# Altium schematic sheet Output, Input.SchDoc("Output, Input")
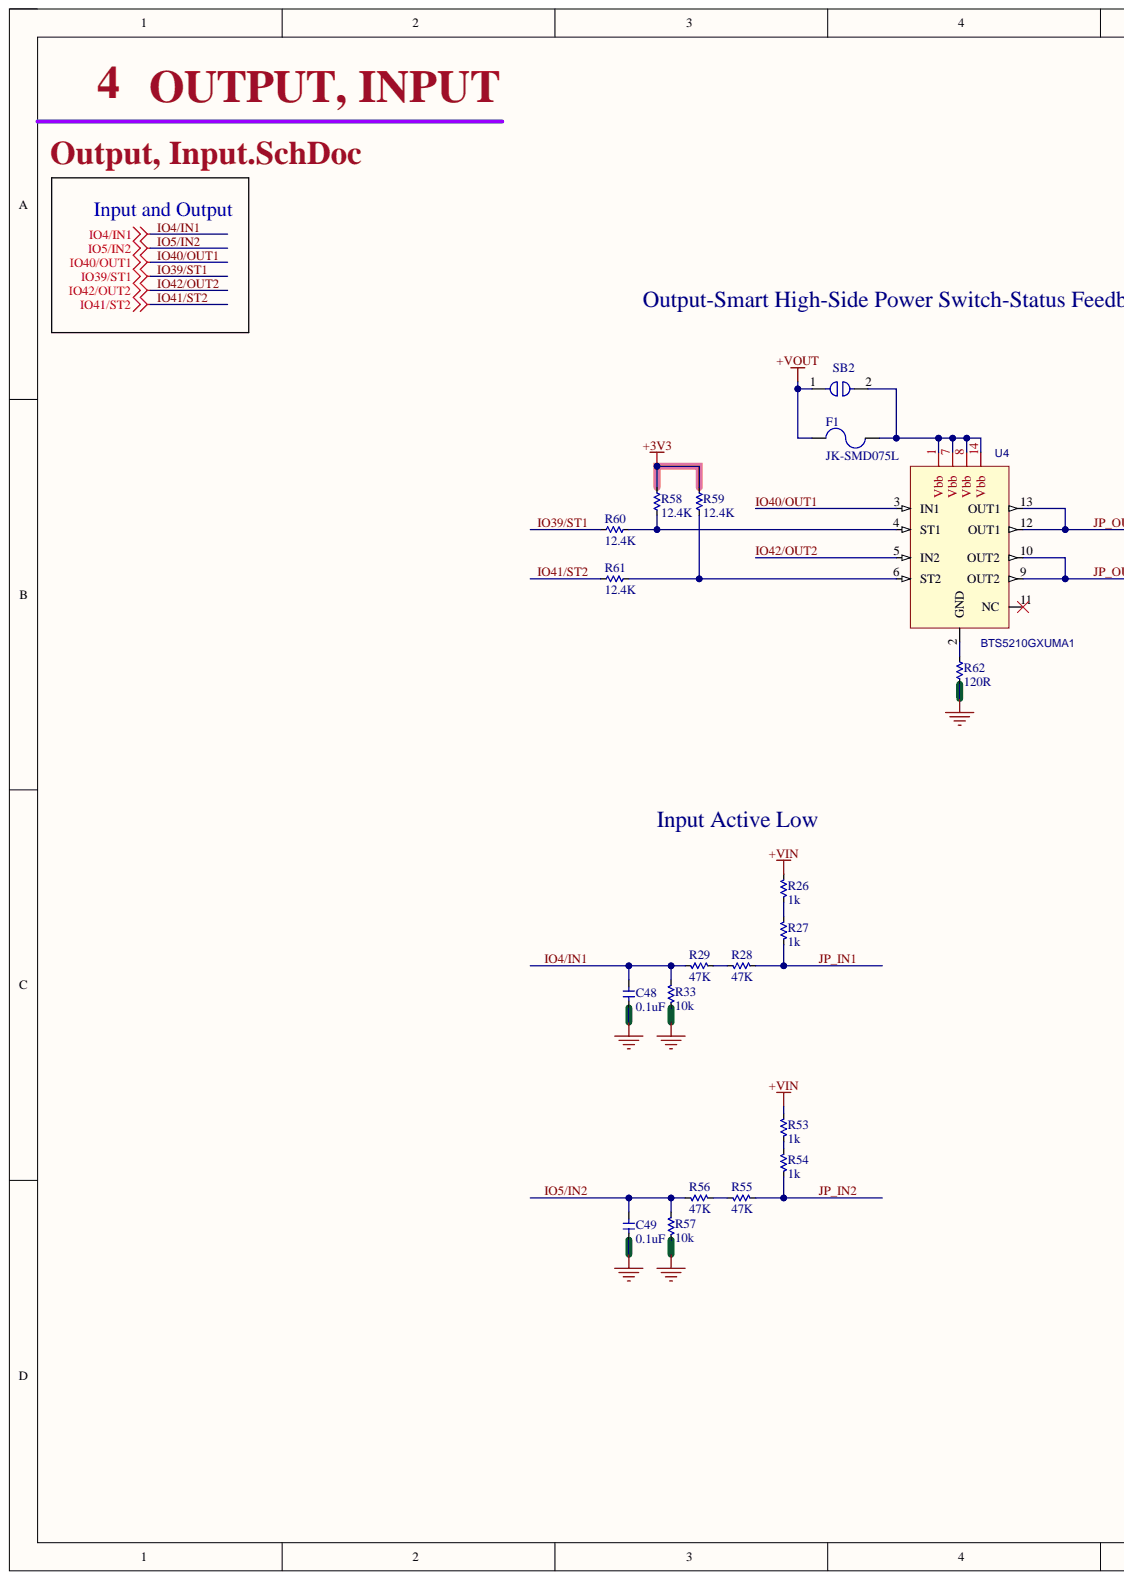
[diagram p0: Output, Input.SchDoc, left]
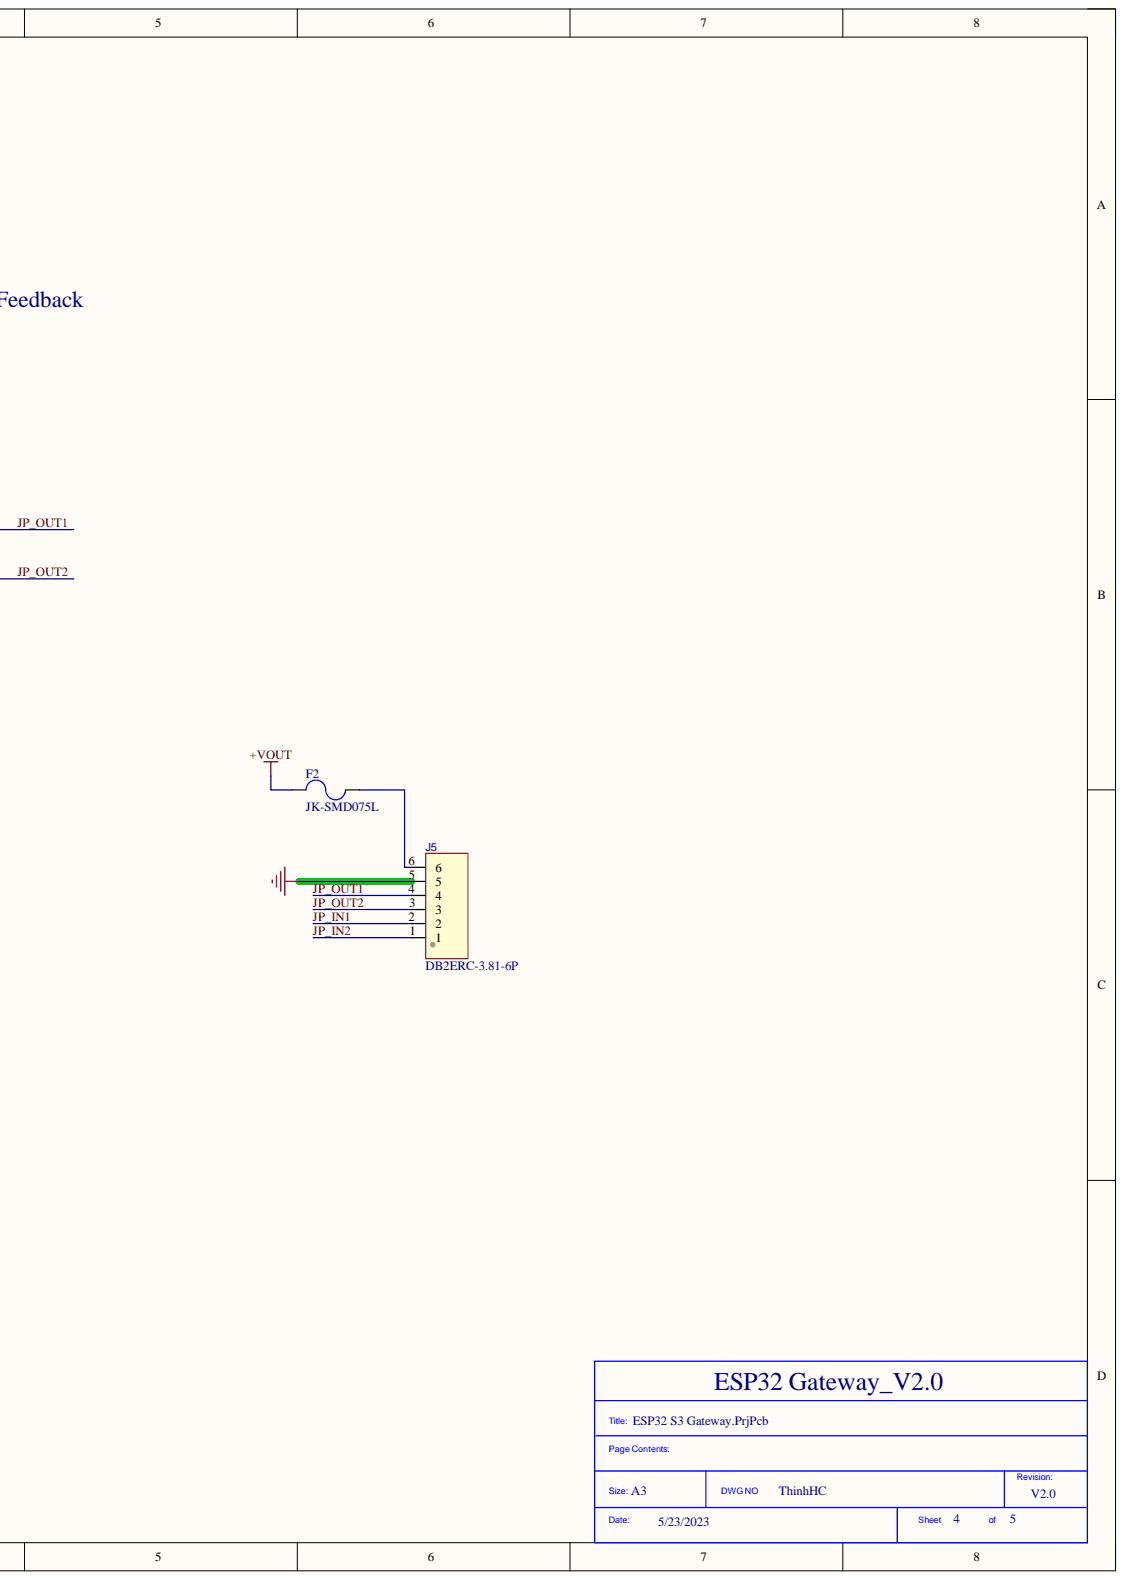
[diagram p1: Output, Input.SchDoc, right]

## Parts
C48  0.1uF  [C_0402]
C49  0.1uF  [C_0402]
F1  JK-SMD075L  [F_1812]
F2  JK-SMD075L  [F_1812]
J5  DB2ERC-3.81-6P  [CONN-TH_6P-P3.81_DB2ERC-3.81-6P]
R26  1k  [R_0603]
R27  1k  [R_0603]
R28  47K  [R_0603]
R29  47K  [R_0603]
R33  10k  [R_0402]
R53  1k  [R_0603]
R54  1k  [R_0603]
R55  47K  [R_0603]
R56  47K  [R_0603]
R57  10k  [R_0402]
R58  12.4K  [R_0402]
R59  12.4K  [R_0402]
R60  12.4K  [R_0402]
R61  12.4K  [R_0402]
R62  120R  [R_0603]
SB2  SOLDERJUMPER_1WAY_OFF  [SOLDERJUMPER_1WAY_OFF]  (value not drawn)  (no BOM)
U4  BTS5210GXUMA1  [PG-DSO-14_L8.8-W4.0-P1.27-LS6.0-BL]

## Nets
+3V3: R58.1 R59.1
+VIN: R26.2 R53.2
+VOUT: F1.1 F2.1 SB2.1
GND: C48.1 C49.1 J5.5 R33.1 R57.1 R62.2
IO4/IN1: C48.2 R29.2 R33.2
IO5/IN2: C49.2 R56.2 R57.2
IO39/ST1: R60.2
IO40/OUT1: U4.3(IN1)
IO41/ST2: R61.1
IO42/OUT2: U4.5(IN2)
JP_IN1: J5.2 R27.1 R28.1
JP_IN2: J5.1 R54.1 R55.1
JP_OUT1: J5.4 U4.12(OUT1) U4.13(OUT1)
JP_OUT2: J5.3 U4.9(OUT2) U4.10(OUT2)
NetF1_2: F1.2 SB2.2 U4.1(Vbb) U4.7(Vbb) U4.8(Vbb) U4.14(Vbb)
NetF2_2: F2.2 J5.6
NetR26_1: R26.1 R27.2
NetR28_2: R28.2 R29.1
NetR53_1: R53.1 R54.2
NetR55_2: R55.2 R56.1
NetR58_2: R58.2 R60.1 U4.4(ST1)
NetR59_2: R59.2 R61.2 U4.6(ST2)
NetR62_1: R62.1 U4.2(GND)

Not connected: U4 11(NC)

## Library data of the parts
C48 C49 = CL05B104KB54PNC: 50V 100nF X7R ±10% 0402 Multilayer Ceramic Capacitors MLCC - SMD/SMT ROHS; Manufacturer: Samsung Electro-Mechanics; Assembly Type: SMT Assembly; Component Kind: Standard; ComponentLink1Description: Digikey Link; ComponentLink1URL: https://www.digikey.com/en/products/detail/yageo/CC0603KRX7R9BB104/2103082?s=N4IgTCBcDaIMwEYEFoFwCzuWZA5AIiALoC%2BQA; ComponentLink2Description: LCSC Link; ComponentLink2URL: https://jlcpcb.com/parts/componentSearch?searchTxt=10k%200603; LCSC Part Number: C307331; Library Name: ESP32 S3 Gateway.SchLib; Manufacturer Part Number: CL05B104KB54PNC; Package: 0402
F1 F2 = PTC_JK-SMD075L: JK-SMD075L 33V 750mA 100A 1.5A 2920  Resettable Fuses ROHS; Manufacturer: Jinrui Electronic Materials Co.; Assembly Type: SMT Assembly; Component Kind: Standard; LCSC Part Number: C920242; Library Name: ESP32 S3 Gateway.SchLib; Manufacturer Part Number: JK-SMD075L; Package: 1812
J5 = DB2ERC-3.81-6P: 250V 10A 6 Bend -40℃~+105℃ 1 3.81mm Green Board Edge/Receptacle-Close Push-Pull,P=3.81mm Pluggable System Terminal Block ROHS; Manufacturer: DIBO; Manufacturer Part Number: DB2ERC-3.81-6P-GN; Assembly Type: Hand Soldering; Component Kind: Standard; LCSC Part Number: C395709; Library Name: ESP32 S3 Gateway.SchLib; Package: Push-Pull,P=3.81mm
R26 R27 R53 R54 = 0603WAF1001T5E: 100mW Thick Film Resistors 75V ±100ppm/℃ ±1% -55℃~+155℃ 1kΩ 0603 Chip Resistor - Surface Mount ROHS; Manufacturer: UNI-ROYAL(Uniroyal Elec); Assembly Type: SMT Assembly; Component Kind: Standard; LCSC Part Number: C21190; Library Name: ESP32 S3 Gateway.SchLib; Manufacturer Part Number: 0603WAF1001T5E; Package: 0603
R28 R29 R55 R56 = 0603WAF4702T5E: 100mW Thick Film Resistors ±1% 47kΩ 0603 Chip Resistor - Surface Mount ROHS; Manufacturer: UNI-ROYAL(Uniroyal Elec); Assembly Type: SMT Assembly; Component Kind: Standard; LCSC Part Number: C25819; Library Name: ESP32 S3 Gateway.SchLib; Manufacturer Part Number: 0603WAF4702T5E; Package: 0603
R33 R57 = 0402WGF1002TCE: 10kΩ 1% 0402 Chip Resistor - Surface Mount ROHS; Manufacturer: UNI-ROYAL(Uniroyal Elec); Assembly Type: SMT Assembly; Component Kind: Standard; LCSC Part Number: C25744; Library Name: ESP32 S3 Gateway.SchLib; Manufacturer Part Number: 0402WGF1002TCE; Package: 0402
R58-R61 = 0402WGF1242TCE: 62.5mW Thick Film Resistors ±1% 12.4kΩ 0402 Chip Resistor - Surface Mount ROHS; Manufacturer: UNI-ROYAL(Uniroyal Elec); Assembly Type: SMT Assembly; Component Kind: Standard; LCSC Part Number: C11692; Library Name: ESP32 S3 Gateway.SchLib; Manufacturer Part Number: 0402WGF1242TCE; Package: 0402
R62 = 0603WAF1200T5E: 100mW Thick Film Resistors 75V ±100ppm/℃ ±1% -55℃~+155℃ 120Ω 0603 Chip Resistor - Surface Mount ROHS; Manufacturer: UNI-ROYAL(Uniroyal Elec); Assembly Type: SMT Assembly; Component Kind: Standard; LCSC Part Number: C22787; Library Name: ESP32 S3 Gateway.SchLib; Manufacturer Part Number: 0603WAF1200T5E; Package: 0603
SB2 = SOLDERJUMPER_1WAY_OFF: GateName_1: G$1; Description: SOLDERJUMPER_1WAY_OFF; DeviceSetName: SOLDERJUMPER_1WAY; Library Name: ESP32 S3 Gateway.SchLib; LibraryName: microbuilder; SymbolName_1: SOLDERJUMPER_1WAY
U4 = BTS5210GXUMA1: BTS5210GXUMA1 5.5V~40V 110mΩ 1.8A SOP-14  Power Distribution Switches ROHS; Manufacturer: Infineon; Assembly Type: AMT Assembly; Component Kind: Standard; LCSC Part Number: C2150070; Library Name: ESP32 S3 Gateway.SchLib; Manufacturer Part Number: BTS5210GXUMA1; Package: DSO-14; spiceSymbolName: BTS5210GXUMA1
